# Altium schematic sheet Main.SchDoc(Main)
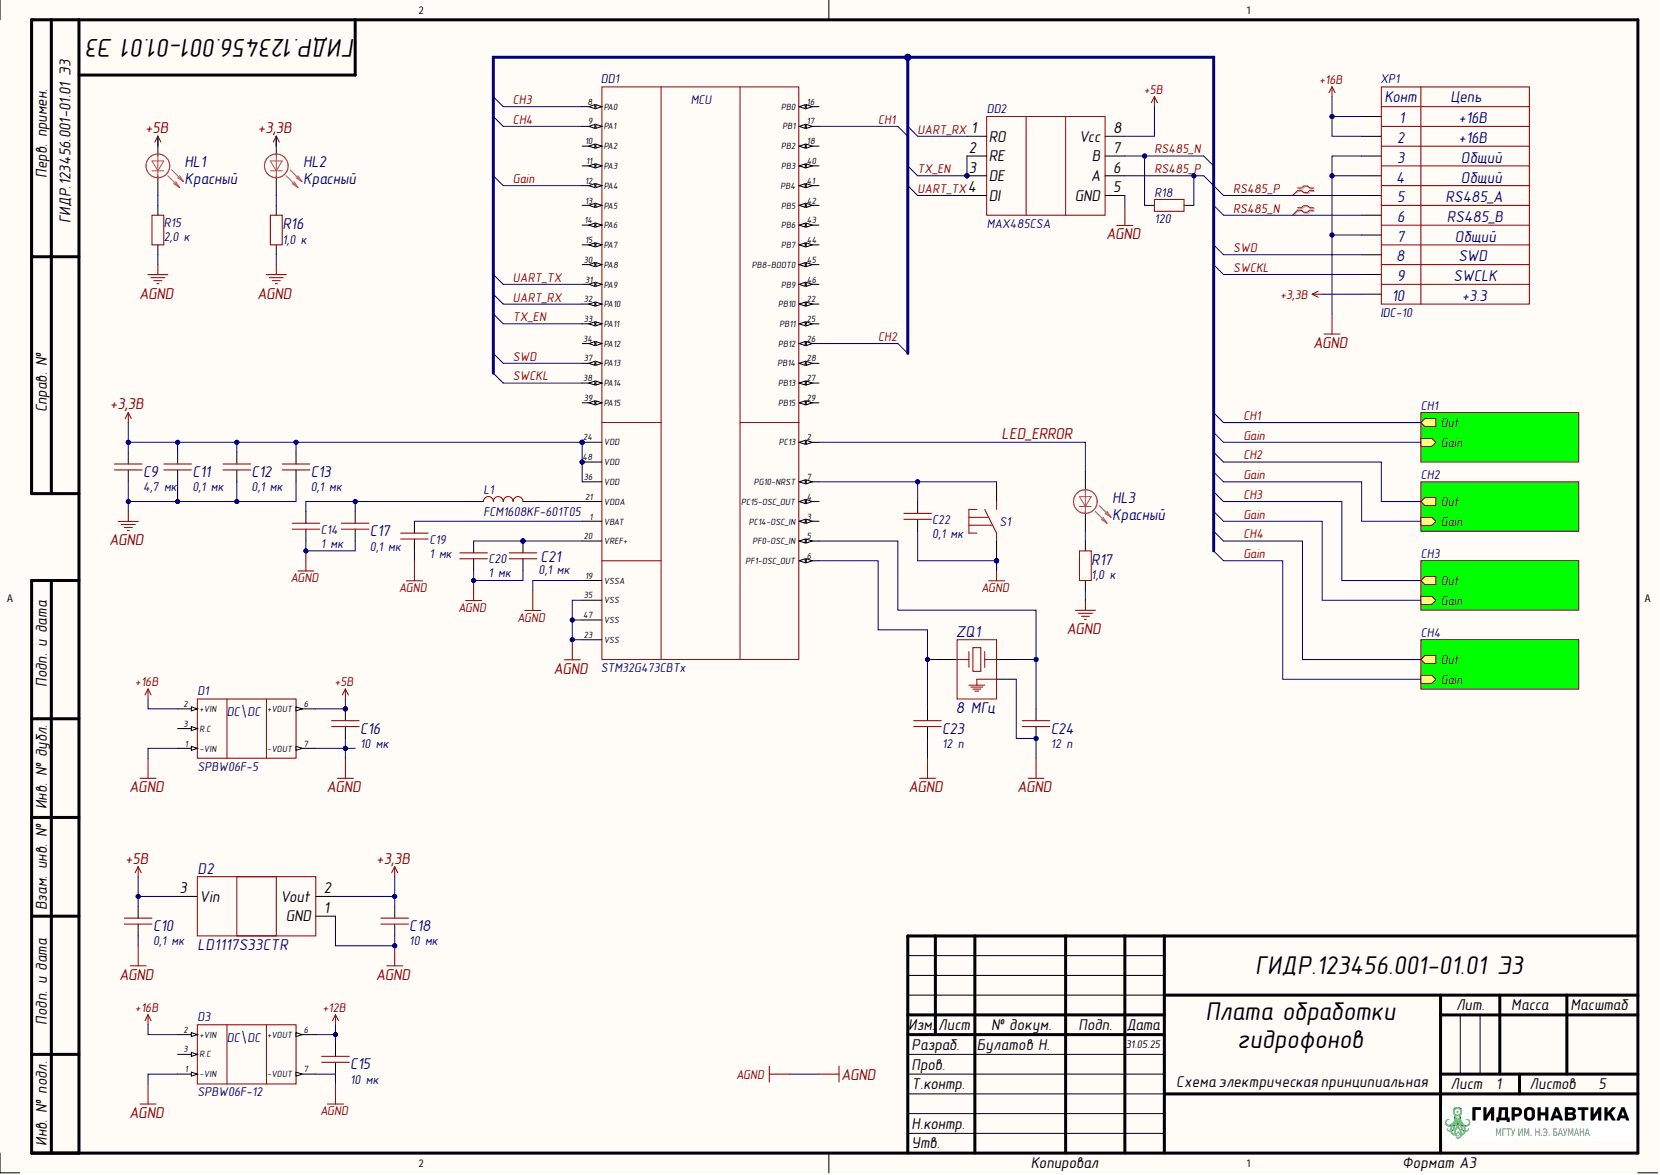
[diagram p0: Main.SchDoc]

## Parts
C9  value 4,7 мк  [1206C]  (hidden comment: Capacitor)
C10  value 0,1 мк  Tolerance: 0,1  [0603C]  (hidden comment: Capacitor)
C11  value 0,1 мк  Tolerance: 0,1  [0603C]  (hidden comment: Capacitor)
C12  value 0,1 мк  Tolerance: 0,1  [0603C]  (hidden comment: Capacitor)
C13  value 0,1 мк  Tolerance: 0,1  [0603C]  (hidden comment: Capacitor)
C14  value 1 мк  [0603C]  (hidden comment: Capacitor)
C15  value 10 мк  [1210C]  (hidden comment: Capacitor)
C16  value 10 мк  [1210C]  (hidden comment: Capacitor)
C17  value 0,1 мк  Tolerance: 0,1  [0603C]  (hidden comment: Capacitor)
C18  value 10 мк  [1210C]  (hidden comment: Capacitor)
C19  value 1 мк  [0603C]  (hidden comment: Capacitor)
C20  value 1 мк  [0603C]  (hidden comment: Capacitor)
C21  value 0,1 мк  Tolerance: 0,1  [0603C]  (hidden comment: Capacitor)
C22  value 0,1 мк  [0603C]  (hidden comment: Capacitor)
C23  value 12 п  [0603C]  (hidden comment: Capacitor)
C24  value 12 п  [0603C]  (hidden comment: Capacitor)
D1  SPBW06F-5  [CONV_SPBW06F-12]
D2  LD1117S33CTR  [SOT223]
D3  SPBW06F-12  [CONV_SPBW06F-12]
DD1  STM32G473CBTx  [LQFP48-7x7mm]
DD2  MAX485CSA  [SOIC-8]
HL1  Color: Красный  [0805D]  (hidden comment: LED)
HL2  Color: Красный  [0805D]  (hidden comment: LED)
HL3  LED  Color: Красный  [0805D]
L1  FCM1608KF-601T05  [0603L]
R15  value 2,0 к  [0603R]  (hidden comment: Resistor)
R16  value 1,0 к  [0603R]  (hidden comment: Resistor)
R17  value 1,0 к  [0603R]  (hidden comment: Resistor)
R18  value 120  [0603R]  (hidden comment: Resistor)
S1  TSS-TD-19XA-XTR  [TSS-TD-19XA-XTR]  (value not drawn)
XP1  IDC-10  Value1: +16В  Value3: Общий  Value5: RS485_A  Value6: RS485_B  Value8: SWD  Value9: SWCLK  Value10: +3.3  [IDC-10]
ZQ1  value 8 МГц  [QSMD3.2x2.5]  (hidden comment: XTAL_GND)

## Nets
+3,3В: C9.2 C11.2 C12.2 C13.2 C18.2 D2.2 DD1.24(VDD) DD1.36(VDD) DD1.48(VDD) HL2.1 XP1.10
+5В: C10.2 C16.2 D1.6(+VOUT) D2.3 DD2.8(Vcc) HL1.1
+12В: C15.2 D3.6(+VOUT)
+16В: D1.2(+VIN) D3.2(+VIN) XP1.1 XP1.2
AGND: C9.1 C10.1 C11.1 C12.1 C13.1 C14.1 C15.1 C16.1 C17.1 C18.1 C19.1 C20.1 C21.1 C22.1 C23.1 C24.1 D1.1(-VIN) D1.7(-VOUT) D2.1 D3.1(-VIN) D3.7(-VOUT) DD1.19(VSSA) DD1.23(VSS) DD1.35(VSS) DD1.47(VSS) DD2.5(GND) R15.1 R16.1 R17.1 S1.1 XP1.3 XP1.4 XP1.7 ZQ1.3
CH1: DD1.17(PB1)
CH2: DD1.26(PB12)
CH3: DD1.8(PA0)
CH4: DD1.9(PA1)
Gain: DD1.12(PA4)
LED_ERROR: DD1.2(PC13) HL3.1
RS485_N: DD2.7(B) R18.2 XP1.6
RS485_P: DD2.6(A) R18.1 XP1.5
SWCKL: DD1.38(PA14) XP1.9
SWD: DD1.37(PA13) XP1.8
TX_EN: DD1.33(PA11) DD2.2(RE) DD2.3(DE)
UART_RX: DD1.32(PA10) DD2.1(RO)
UART_TX: DD1.31(PA9) DD2.4(DI)
NetC14_2: C14.2 C17.2 L1.1
NetC19_2: C19.2 DD1.1(VBAT)
NetC20_2: C20.2 C21.2 DD1.20(VREF+)
NetC22_2: C22.2 DD1.7(PG10-NRST) S1.2
NetC23_2: C23.2 DD1.6(PF1-OSC_OUT) ZQ1.1
NetC24_2: C24.2 DD1.5(PF0-OSC_IN) ZQ1.2
NetD1_3: D1.3(R.C)
NetD3_3: D3.3(R.C)
NetDD1_3: DD1.3(PC14-OSC_IN)
NetDD1_4: DD1.4(PC15-OSC_OUT)
NetDD1_10: DD1.10(PA2)
NetDD1_11: DD1.11(PA3)
NetDD1_13: DD1.13(PA5)
NetDD1_14: DD1.14(PA6)
NetDD1_15: DD1.15(PA7)
NetDD1_16: DD1.16(PB0)
NetDD1_18: DD1.18(PB2)
NetDD1_21: DD1.21(VDDA) L1.2
NetDD1_22: DD1.22(PB10)
NetDD1_25: DD1.25(PB11)
NetDD1_27: DD1.27(PB13)
NetDD1_28: DD1.28(PB14)
NetDD1_29: DD1.29(PB15)
NetDD1_30: DD1.30(PA8)
NetDD1_34: DD1.34(PA12)
NetDD1_39: DD1.39(PA15)
NetDD1_40: DD1.40(PB3)
NetDD1_41: DD1.41(PB4)
NetDD1_42: DD1.42(PB5)
NetDD1_43: DD1.43(PB6)
NetDD1_44: DD1.44(PB7)
NetDD1_45: DD1.45(PB8-BOOT0)
NetDD1_46: DD1.46(PB9)
NetHL1_2: HL1.2 R15.2
NetHL2_2: HL2.2 R16.2
NetHL3_2: HL3.2 R17.2

## Library data of the parts
C9 = Capacitor_4,7u_10_50V_X7R_1206C: Конденсатор; Capacity (nF): 4700; Database Table Name: Capacitors_ceramic$; Dielectric: X7R; Library Path2: Schematic\Capacitor.SchLib; Tolerance: 0,1; Value: 4,7 мк; Voltage (V): 50; Component Kind: Standard; Library Name: Hydrolib.DbLib; Part Number: Capacitor_4,7u_10_50V_X7R_1206C
C10 = Capacitor_0,1u_10_50V_X7R_0603C: Конденсатор; Capacity (nF): 100; Database Table Name: Capacitors_ceramic$; Dielectric: X7R; Library Path2: Schematic\Capacitor.SchLib; Value: 0,1 мк; Voltage (V): 50; Component Kind: Standard; Library Name: Hydrolib.DbLib; Part Number: Capacitor_0,1u_10_50V_X7R_0603C
C11-C13 C17 C21 = Capacitor_0,1u_10_50V_X7R_0603C: Конденсатор; Capacity (nF): 100; Database Table Name: Capacitors_ceramic$; Dielectric: X7R; Library Path2: Schematic\Capacitor.SchLib; Voltage (V): 50; Component Kind: Standard; Library Name: Hydrolib.DbLib; Part Number: Capacitor_0,1u_10_50V_X7R_0603C
C14 = Capacitor_1u_10_50V_X7R_0603C: Конденсатор 0603C ёмкостью 1 мк 10%, 50 В, X7R; Capacity (nF): 1000; Database Table Name: Capacitors_ceramic$; Value: 1 мк; Voltage (V): 50; Component Kind: Standard; Dielectric: X7R; Library Name: Hydrolib.DbLib; Part Number: Capacitor_1u_10_50V_X7R_0603C; Tolerance: 10%
C15 C16 C18 = Capacitor_10u_10_35V_X7R_1210C: Конденсатор; Capacity (nF): 10000; Database Table Name: Capacitors_ceramic$; Dielectric: X7R; Library Path2: Schematic\Capacitor.SchLib; Tolerance: 0,1; Voltage (V): 35; Component Kind: Standard; Library Name: Hydrolib.DbLib; Part Number: Capacitor_10u_10_35V_X7R_1210C
C19 C20 = Capacitor_1u_10_50V_X7R_0603C: Конденсатор 0603C ёмкостью 1 мк 10%, 50 В, X7R; Capacity (nF): 1000; Database Table Name: Capacitors_ceramic$; Voltage (V): 50; Component Kind: Standard; Dielectric: X7R; Library Name: Hydrolib.DbLib; Part Number: Capacitor_1u_10_50V_X7R_0603C; Tolerance: 10%
C22 = Capacitor_0,1u_10_50V_X7R_0603C: Конденсатор 0603C ёмкостью 0,1 мк 10%, 50 В, X7R; Capacity (nF): 100; Database Table Name: Capacitors_ceramic$; Value: 0,1 мк; Voltage (V): 50; Component Kind: Standard; Dielectric: X7R; Library Name: Hydrolib.DbLib; Part Number: Capacitor_0,1u_10_50V_X7R_0603C; Tolerance: 10%
C23 C24 = 0,1 мк: Конденсатор 12п; Database Table Name: Capacitors$; Component Kind: Standard; Library Name: Hydrolib.DbLib; Part Number: Capacitor
D1 D3 = SPBW06F-12: Isolated Module DC DC Converter 1 Output 12V - - - 500mA 9V - 36V Input; MF: MEAN WELL; Check_prices: https://www.snapeda.com/parts/SPBW06F-12/Mean+Well/view-part/?ref=eda; Component Kind: Standard; Library Name: SPBW06F-12.SchLib; MP: SPBW06F-12; Package: SIP-8 Mean Well; SnapEDA_Link: https://www.snapeda.com/parts/SPBW06F-12/Mean+Well/view-part/?ref=snap
D2 = LD1117S33CTR: Линейный стабилизатор напряжения; Database Table Name: Chips$; Component Kind: Standard; Library Name: Hydrolib.DbLib; Part Number: LD1117S33CTR
DD1 = STM32G473CBTx: Mainstream Arm Cortex-M4 core with DSP and FPU, 170MHz with 128Kbytes of Flash memory, Math Accelerator, High Analog level integration; Manufacturer_Part_Number: stm32g4_lqfp48_t1; Built_By: EMA_Jessica; Vendor: STMicroelectronics; Component Kind: Standard; Library Name: ST Microelectronics STM32 G4.SchLib; RefDes: RefDes; Type: DEV; Value: Value; Copyright: Copyright (C) 2016 Accelerated Designs. All rights reserved
DD2 = MAX485CSA: RS-485 приёмопередатчик; Database Table Name: Chips$; Component Kind: Standard; Library Name: Hydrolib.DbLib; Part Number: MAX485CSA
HL1-HL3 = LED: Светодиод; Database Table Name: Other$; Component Kind: Standard; Library Name: Hydrolib.DbLib; Part Number: LED
L1 = Inductance: Катушка индуктивности; Database Table Name: Inductance$; Component Kind: Standard; Library Name: Hydrolib.DbLib; Part Number: Inductance
R15 = Resistor_2.0k_1_0603: Резистор номиналом 2,0 кОм 1%; Database Table Name: Resistors$; Component Kind: Standard; Library Name: Hydrolib.DbLib; Part Number: Resistor_2.0k_1_0603; Tolerance: 1%
R16 R17 = Resistor_1.0k_1_0603: Резистор номиналом 1,0 кОм и точностью 1%; Database Table Name: Resistors$; Component Kind: Standard; Library Name: Hydrolib.DbLib; Part Number: Resistor_1.0k_1_0603; Tolerance: 1%
R18 = Resistor_120_1_0603: Резистор номиналом 120 Ом 1%; Database Table Name: Resistors$; Component Kind: Standard; Library Name: Hydrolib.DbLib; Part Number: Resistor_120_1_0603; Tolerance: 1%
S1 = TSS-TD-19XA-XTR: Тактовая кнопка; Database Table Name: Other$; Автор: glebmih; Component Kind: Standard; Datasheet: https://cdn.promelec.ru/upload/items/2023/04/17/TSS-TD-19XA.pdf; Library Name: Hydrolib.DbLib; Part Number: TSS-TD-19XA-XTR
XP1 = IDC-10: 10-пиновый разъём с ключём; Database Table Name: Connectors$; System: Connector; Component Kind: Standard; Library Name: Hydrolib.DbLib; Part Number: IDC-10; Value2: +16В; Value4: Общий; Value7: Общий
ZQ1 = XTAL_GND: Кварцевый резонатор с входом земли; Database Table Name: Other$; Component Kind: Standard; Library Name: Hydrolib.DbLib; Part Number: XTAL_GND
